# Altium schematic sheet Voltage_Regulator.SchDoc(Voltage_Regulator)
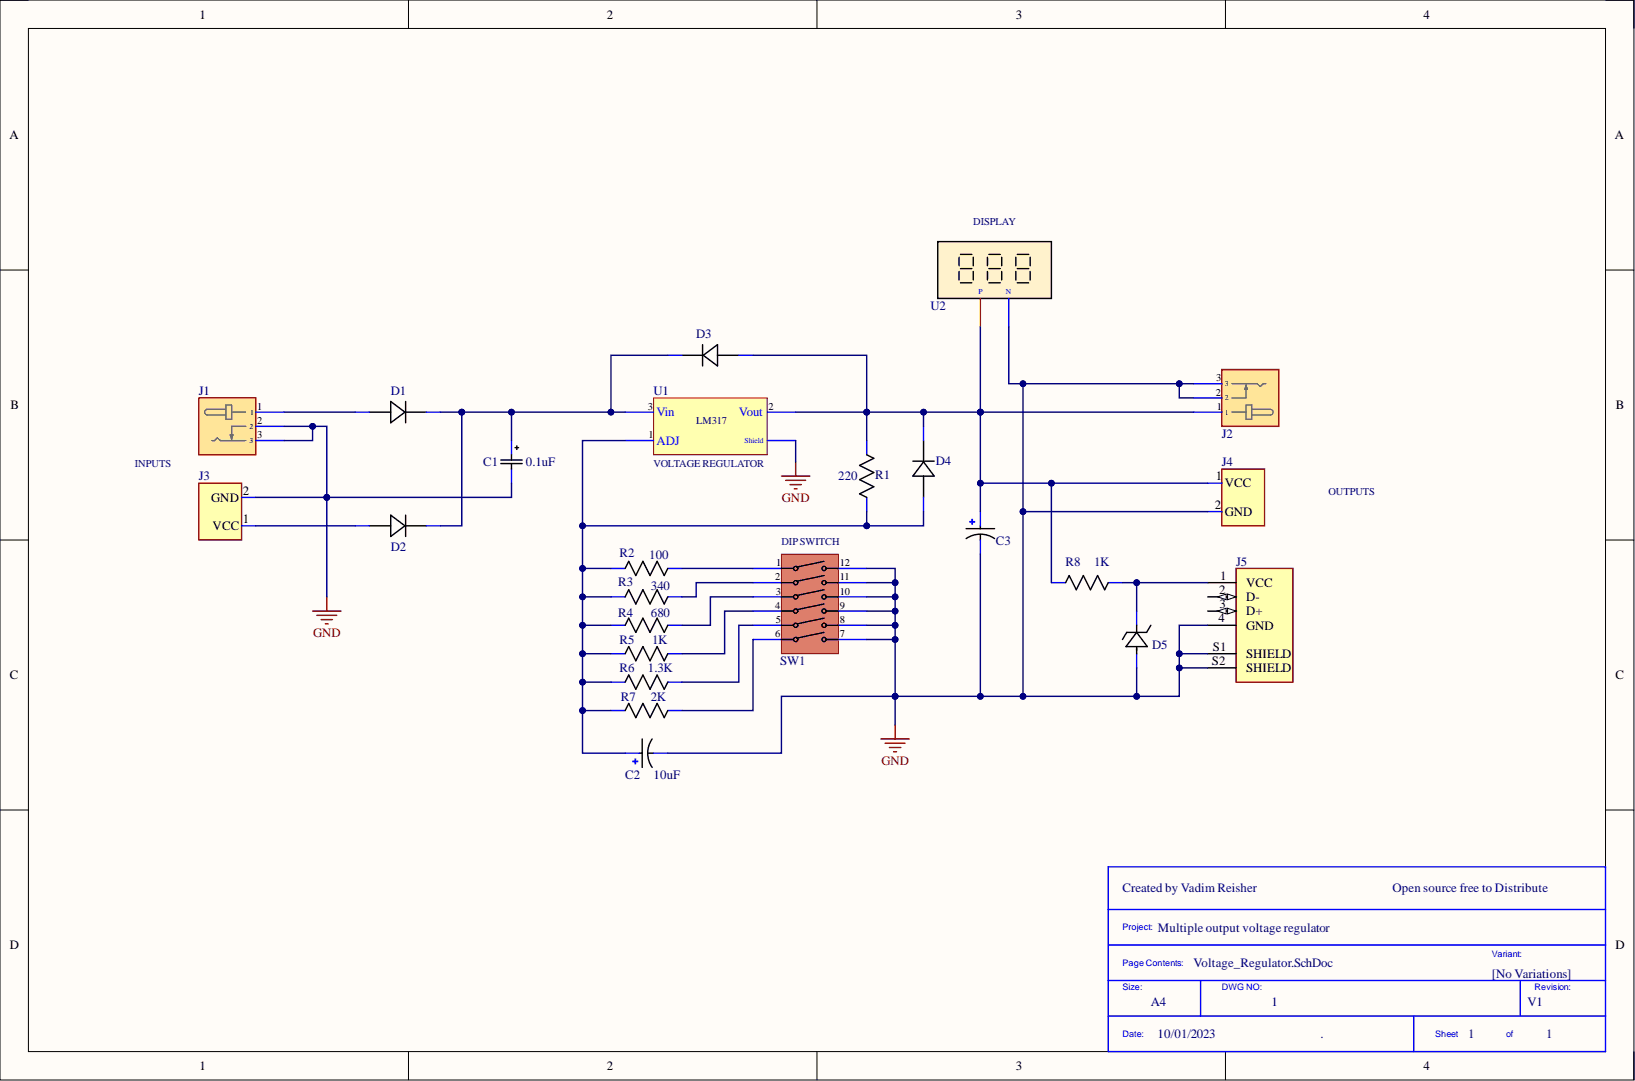
[diagram p0: Voltage_Regulator.SchDoc]

## Parts
C1  0.1uF  [CAP_UEP_5X11_NCH]
C2  10uF  [CAPPRD250W50D500H1100]
C3  ECA-1HM100I  [CAPPRD250W50D500H1100]  (value not drawn)
D1  1N4002  [DIOAD520W79L463D238]  (value not drawn)
D2  1N4002  [DIOAD520W79L463D238]  (value not drawn)
D3  1N4002  [DIOAD520W79L463D238]  (value not drawn)
D4  1N4002  [DIOAD520W79L463D238]  (value not drawn)
D5  1N5231B  [AFL-W2C8.9D]  (value not drawn)
J1  PJ-002A  [PJ-002A]  (value not drawn)
J2  PJ-002A  [PJ-002A]  (value not drawn)
J3  TB003-500-P02BE  [HDR1x2_TB003-500]  (value not drawn)
J4  TB003-500-P02BE  [HDR1x2_TB003-500]  (value not drawn)
J5  USB-A-S-X-X-TH  [SAMTEC_USB-A-S-X-X-TH]  (value not drawn)
R1  220  [CMF55_VIS]
R2  100  [CFR25_YAG]
R3  340  [MF25_YAG]
R4  680  [CFR25_YAG]
R5  1K  [CFR25_YAG]
R6  1.3K  [CFR25_YAG]
R7  2K  [CFR25_YAG]
R8  1K  [CFR25_YAG]
SW1  Case/Package: DIP  [BD06AV]  (hidden comment: BD06AV)
U1  LM317AT_HeatSink  [TO254P1054X470X1955-3 - HeatSink]  (value not drawn)
U2  DSN DVM 368  [DSN-DVM-368]  (value not drawn)

## Nets
GND: C1.2 C2.N C3.N D5.2 J1.2 J1.3 J2.2 J2.3 J3.2 J4.2 J5.4(GND) J5.S1(SHIELD) J5.S2(SHIELD) SW1.7 SW1.8 SW1.9 SW1.10 SW1.11 SW1.12 U1.4(Shield) U2.2
NetC1_1: C1.1 D1.C D2.C D3.C U1.3(Vin)
NetC2_P: C2.P D4.A R1.2 R2.1 R3.1 R4.1 R5.1 R6.1 R7.1 U1.1(ADJ)
NetC3_P: C3.P D3.A D4.C J2.1 J4.1 R1.1 R8.1 U1.2(Vout) U2.1
NetD1_A: D1.A J1.1
NetD2_A: D2.A J3.1
NetD5_1: D5.1 J5.1(VCC) R8.2
NetR2_2: R2.2 SW1.1
NetR3_2: R3.2 SW1.2
NetR4_2: R4.2 SW1.3
NetR5_2: R5.2 SW1.4
NetR6_2: R6.2 SW1.5
NetR7_2: R7.2 SW1.6

Not connected: J5 2(D-) 3(D+)

## Library data of the parts
C1 = UKT1H0R1MDD1TD: CAP ALUM 0.1UF 20% 50V RADIAL; Mfr_Name: Nichicon; Type: DEV; Component Kind: Standard; Digi-Key_Part_Number_1: 493-10656-1-ND; Digi-Key_Part_Number_2: 493-10656-3-ND; Library Name: Voltage_Regulator_SchLib.SchLib; Manufacturer_Part_Number: UKT1H0R1MDD1TD; RefDes: RefDes; Copyright: Copyright (C) 2023 Ultra Librarian. All rights reserved.
C2 C3 = ECA-1HM100I: CAP ALUM 10UF 20% 50V RADIAL; Manufacturer: Panasonic; Dissipation Factor: 0.12%; Lead Diameter: 0.5mm; Lead/Base Style: Straight; Leakage Current: 3uA; Life (Hours): 2000Hour; Ripple Current: 65mA; Case/Package: Radial; Category: Aluminum Electrolytic Capacitors; Component Kind: Standard; ComponentLink1Description: https://datasheet.ciiva.com/16201/aba0000ce12-16201701.pdf?src-supplier=Future+Electronics; ComponentLink1URL: https://datasheet.ciiva.com/16201/aba0000ce12-16201701.pdf?src-supplier=Future+Electronics; ComponentLink2Description: https://datasheet.ciiva.com/24234/aba0000ce12-465472-24234949.pdf?src-supplier=Mouser; ComponentLink2URL: https://datasheet.ciiva.com/24234/aba0000ce12-465472-24234949.pdf?src-supplier=Mouser; ComponentLink3Description: https://datasheet.ciiva.com/28677/aba0000ce12-28677830.pdf?src-supplier=Element14; ComponentLink3URL: https://datasheet.ciiva.com/28677/aba0000ce12-28677830.pdf?src-supplier=Element14; ComponentLink4Description: https://datasheet.ciiva.com/7794/1907780-7794379.pdf?src-supplier=Element14; ComponentLink4URL: https://datasheet.ciiva.com/7794/1907780-7794379.pdf?src-supplier=Element14; ComponentLink5Description: https://datasheet.ciiva.com/2984/aba0000ce12-2984335.pdf?src-supplier=Avnet; ComponentLink5URL: https://datasheet.ciiva.com/2984/aba0000ce12-2984335.pdf?src-supplier=Avnet; ComponentLink6Description: https://datasheet.ciiva.com/6121/aba0000ce12-6121535.pdf?src-supplier=Farnell; ComponentLink6URL: https://datasheet.ciiva.com/6121/aba0000ce12-6121535.pdf?src-supplier=Farnell; ComponentLink7Description: https://datasheet.ciiva.com/14608/70067785-14608821.pdf?src-supplier=Allied+Electronics; ComponentLink7URL: https://datasheet.ciiva.com/14608/70067785-14608821.pdf?src-supplier=Allied+Electronics; ComponentLink8Description: https://datasheet.ciiva.com/15197/getdatasheetpartid-238364-15197946.pdf?src-supplier=Verical; ComponentLink8URL: https://datasheet.ciiva.com/15197/getdatasheetpartid-238364-15197946.pdf?src-supplier=Verical; Construction: RADIAL; Diameter: 5mm; Height: 11mm; Height - Seated (Max): 0.472inch; Lead Free: Lead Free; Lead Pitch: 2.5mm; Length: 11mm; Library Name: Voltage_Regulator_SchLib.SchLib; Manufacturer Part Number: ECA-1HM100I; Max Operating Temperature: 85°C; Min Operating Temperature: -40°C; Mounting Technology: Through Hole,PCB; Package Quantity: 2000; Packaging: Tape and Reel; Pricing 1: 2000=0.05900, 10000=0.05800, 20000=0.05700 (USD); Radiation Hardening: No; RoHS: Yes; RoHS Compliant: Yes; Series: M; Stock 1: 6000; Supplier 1: Farnell; Supplier Part Number 1: 2917901; Termination: Radial; Tolerance: 20%; Value: 10uF; Voltage: 50V; Voltage Rating: 50V; Voltage Rating (DC): 50V
D1-D4 = 1N4002: Diode Standard 100 V 1A Through Hole DO-41; MF: NTE Electronics, Inc; Availability: In Stock; Check_prices: https://www.snapeda.com/parts/1N4002/NTE+Electronics/view-part/?ref=eda; Component Kind: Standard; Library Name: Voltage_Regulator_SchLib.SchLib; MP: 1N4002; Package: DO-41 NTE Electronics; Price: None; Purchase-URL: https://pricing.snapeda.com/search/part/1N4002/?ref=eda; SnapEDA_Link: https://www.snapeda.com/parts/1N4002/NTE+Electronics/view-part/?ref=snap
D5 = 1N5231B: DIODE ZENER 5.1V 500MW DO35; Mfr_Name: onsemi; Type: DEV; Component Kind: Standard; Library Name: Voltage_Regulator_SchLib.SchLib; Manufacturer_Part_Number: 1N5231B; RefDes: RefDes; Copyright: Copyright (C) 2023 Ultra Librarian. All rights reserved.
J1 J2 = PJ-002A: 2.0 x 6.5 mm, 2.5 A, Horizontal, Through Hole, Dc Power Jack Connector; Manufacturer: CUI; Current Rating: 2.5A; Gender: Male; Insulation Resistance: 50MR; Number of Conductors: 2; Shielding: Unshielded; Voltage Rating: 24V; Category: Uncategorized; Color: Black; Component Kind: Standard; ComponentLink1Description: http://www.mouser.com/ds/2/670/pj-002a-515880.pdf?src-supplier=Mouser; ComponentLink1URL: http://www.mouser.com/ds/2/670/pj-002a-515880.pdf?src-supplier=Mouser; ComponentLink2Description: http://www.cui.com/Product/Resource/FuturePDF/PJ-002A.pdf?src-supplier=Future+Electronics; ComponentLink2URL: http://www.cui.com/Product/Resource/FuturePDF/PJ-002A.pdf?src-supplier=Future+Electronics; ComponentLink3Description: https://www.cui.com/product/resource/digikeypdf/pj-002a.pdf?src-supplier=Digi-Key; ComponentLink3URL: https://www.cui.com/product/resource/digikeypdf/pj-002a.pdf?src-supplier=Digi-Key; Connector Type: Jack; Contact Gender: Male; Contact Material: Copper Alloy,Copper; Contact Plating: Tin,Nickel; Diameter: 2.1mm; Flammability Rating: UL94 V-0; Housing Color: Black; Housing Material: Thermoplastic; Lead Free: Lead Free; Library Name: Voltage_Regulator_SchLib.SchLib; Manufacturer Part Number: PJ-002A; Max Operating Temperature: 85°C; Min Operating Temperature: -25°C; Mounting Technology: Through Hole; Orientation: Horizontal; Package Quantity: 500; Packaging: Bulk; RoHS: Yes; RoHS Compliant: Yes; Series: -; Supplier 1: Arrow Electronics; Supplier Part Number 1: PJ-002A; Termination: Through Hole
J3 J4 = 1x2 Header: 2 Position Wire to Board Terminal Block Horizontal with Board 0.197" (5.00mm) Through Hole; Manufacturer: CUI Devices; Category: Terminal Blocks; Component Kind: Standard; Library Name: Voltage_Regulator_SchLib.SchLib; Manufacturer Part Number: TB003-500-P02BE; Pricing 1: 1=0.73000, 10=0.39800, 25=0.29720, 50=0.26500, 100=0.25990, 250=0.22868, 500=0.20790, 3000=0.14553, 6000=0.14033 (USD); RoHS: Yes; Stock 1: 20513; Supplier 1: Digi-Key; Supplier Part Number 1: 102-6161-ND
J5 = USB-A-S-X-X-TH: USB-A (USB TYPE-A) USB 2.0 Receptacle Connector 4 Position Through Hole, Right Angle; MF: Samtec; MP: USB-A-S-S-W-TH; Component Kind: Standard; Digi-Key_Part_Number: SAM10373-ND; Library Name: Voltage_Regulator_SchLib.SchLib; Package: None; SnapEDA_Link: https://www.snapeda.com/parts/USB-A-S-S-W-TH/Samtec%20Inc./view-part/423470/?ref=snap; Toby_UK-Purchase-URL: https://snapeda.com/shop?store=Toby+UK&id=423470
R1 = RN55D2200FRE6_1: RES 220 OHM 1/8W 1% AXIAL; Mfr_Name: Vishay; Component Kind: Standard; Digi-Key_Part_Number_1: RN55D2200FRE6-ND; Digi-Key_Part_Number_2: 541-RN55D2200FRE6CT-ND; Digi-Key_Part_Number_3: 541-RN55D2200FRE6TR-ND; Library Name: Voltage_Regulator_SchLib.SchLib; Manufacturer_Part_Number: RN55D2200FRE6; RefDes: RefDes; Type: DEV; Copyright: Copyright (C) 2022 Ultra Librarian. All rights reserved.
R2 = CFR-25JR-52-100R: RES 100 OHM 5% 1/4W AXIAL; Mfr_Name: Yageo; Component Kind: Standard; Digi-Key_Part_Number_1: 100QTR-ND; Library Name: Voltage_Regulator_SchLib.SchLib; Manufacturer_Part_Number: CFR-25JR-52-100R; RefDes: RefDes; Type: DEV; Copyright: Copyright (C) 2022 Ultra Librarian. All rights reserved.
R3 = MFR-25FBF52-340R: RES 340 OHM 1% 1/4W AXIAL; Manufacturer: Yageo; Max Power Dissipation: 250mW; Temperature Coefficient: 100ppm/°C; Value: 340R; Voltage Rating: 250V; Voltage Rating (DC): 200V; Case/Package: Axial; Category: Through-Hole Resistors; Component Kind: Standard; ComponentLink1Description: http://www.mouser.com/ds/2/447/Yageo%20LR_MFR_2013-467719.pdf?src-supplier=Mouser; ComponentLink1URL: http://www.mouser.com/ds/2/447/Yageo%20LR_MFR_2013-467719.pdf?src-supplier=Mouser; ComponentLink2Description: http://www.yageo.com/documents/recent/Yageo%20LR_MFR_2013.pdf?src-supplier=Digi-Key; ComponentLink2URL: http://www.yageo.com/documents/recent/Yageo%20LR_MFR_2013.pdf?src-supplier=Digi-Key; Length: 0.248inch; Library Name: Voltage_Regulator_SchLib.SchLib; Manufacturer Part Number: MFR-25FBF52-340R; Max Operating Temperature: 155°C; Min Operating Temperature: -55°C; Number of Terminations: 2; Package Quantity: 200; Packaging: Bulk; Power Rating: 250mW; Pricing 1: 1=0.10000, 10=0.08100, 25=0.05600, 50=0.04360, 100=0.03110, 250=0.02428, 500=0.01928, 1000=0.01369, 5000=0.01058 (USD); Resistor Type: Metal Film; RoHS: Yes; Stock 1: 13988; Supplier 1: Digi-Key; Supplier Part Number 1: 340XBK-ND; Termination: Axial; Tolerance: 1%
R4 = CFR-25JB-52-680R: RES 680 OHM 5% 1/4W AXIAL; Manufacturer: Yageo; Max Power Dissipation: 250mW; Temperature Coefficient: 500ppm/°C; Value: 680R; Case/Package: Axial; Category: Through-Hole Resistors; Component Kind: Standard; ComponentLink1Description: http://www.yageo.com/NewPortal/yageodocoutput?fileName=/pdf/throughhole/Yageo_LR_CFR_2013.pdf&src-supplier=Digi-Key; ComponentLink1URL: http://www.yageo.com/NewPortal/yageodocoutput?fileName=/pdf/throughhole/Yageo_LR_CFR_2013.pdf&src-supplier=Digi-Key; Length: 0.248inch; Library Name: Voltage_Regulator_SchLib.SchLib; Manufacturer Part Number: CFR-25JB-52-680R; Max Operating Temperature: 155°C; Min Operating Temperature: -55°C; Number of Terminations: 2; Package Quantity: 200; Packaging: Bulk; Pricing 1: 10=0.04700, 100=0.02200, 500=0.01200, 2500=0.01000, 5000=0.00900, 10000=0.00900, 50000=0.00900 (USD); Resistor Type: Carbon Film; RoHS: Yes; RoHS Compliant: Yes; Series: Carbon Film; Stock 1: 10000; Supplier 1: Farnell; Supplier Part Number 1: 3951871; Tolerance: 5%
R5 R8 = CFR-25JR-52-1K: RES 1K OHM 5% 1/4W AXIAL; Manufacturer: Yageo; Lead Diameter: 0.55mm; Max Power Dissipation: 250mW; Temperature Coefficient: 350ppm/°C; Value: 1kR; Voltage Rating: 250V; Case/Package: Conformal; Category: Through-Hole Resistors; Component Kind: Standard; ComponentLink1Description: https://datasheet.ciiva.com/26863/getdatasheetpartid-671236-26863217.pdf?src-supplier=Verical; ComponentLink1URL: https://datasheet.ciiva.com/26863/getdatasheetpartid-671236-26863217.pdf?src-supplier=Verical; Construction: Cylindrical; Contact Plating: Tin,Matte; Diameter: 2.4mm; Lead Free: Lead Free; Length: 6.3mm; Library Name: Voltage_Regulator_SchLib.SchLib; Manufacturer Part Number: CFR-25JR-52-1K; Max Operating Temperature: 155°C; Military Standard: Not; Min Operating Temperature: -55°C; Mounting Technology: Through Hole; Number of Terminations: 2; Package Quantity: 5000; Packaging: Tape and Reel; Power Rating: 250mW; Pricing 1: 10=0.04700, 100=0.02200, 500=0.01600, 1000=0.01200, 2500=0.01000, 5000=0.00800, 25000=0.00800 (USD); Radiation Hardening: No; Resistor Type: Carbon Film; RoHS: Yes; RoHS Compliant: Yes; Series: CFR; Stock 1: 24840; Supplier 1: Farnell; Supplier Part Number 1: 3951801; Termination: Axial; Tolerance: 5%
R6 = CFR-25JB-52-1K3: RES 1.3K OHM 5% 1/4W AXIAL; Manufacturer: Yageo; Max Power Dissipation: 250mW; Temperature Coefficient: 500ppm/°C; Value: 1.3kR; Case/Package: Axial; Category: Through-Hole Resistors; Component Kind: Standard; ComponentLink1Description: http://www.yageo.com/NewPortal/yageodocoutput?fileName=/pdf/throughhole/Yageo_LR_CFR_2013.pdf&src-supplier=Digi-Key; ComponentLink1URL: http://www.yageo.com/NewPortal/yageodocoutput?fileName=/pdf/throughhole/Yageo_LR_CFR_2013.pdf&src-supplier=Digi-Key; Length: 0.248inch; Library Name: Voltage_Regulator_SchLib.SchLib; Manufacturer Part Number: CFR-25JB-52-1K3; Max Operating Temperature: 155°C; Min Operating Temperature: -55°C; Number of Terminations: 2; Package Quantity: 200; Packaging: Bulk; Pricing 1: 1=0.10000, 10=0.06800, 25=0.04680, 50=0.03640, 100=0.02600, 250=0.02028, 500=0.01612, 1000=0.01144, 5000=0.00884 (USD); Resistor Type: Carbon Film; RoHS: Yes; RoHS Compliant: Yes; Series: Carbon Film; Stock 1: 702; Supplier 1: Digi-Key; Supplier Part Number 1: 1.3KQBK-ND; Tolerance: 5%
R7 = CFR-25JR-52-2K: RES 2K OHM 5% 1/4W AXIAL; Manufacturer: Yageo; Lead Diameter: 0.55mm; Max Power Dissipation: 250mW; Temperature Coefficient: 500ppm/°C; Value: 2kR; Voltage Rating: 250V; Case/Package: Axial; Category: Through-Hole Resistors; Component Kind: Standard; ComponentLink1Description: http://www.mouser.com/ds/2/447/Yageo%20LR_CFR_2013-540723.pdf?src-supplier=Mouser; ComponentLink1URL: http://www.mouser.com/ds/2/447/Yageo%20LR_CFR_2013-540723.pdf?src-supplier=Mouser; ComponentLink2Description: http://www.yageo.com/documents/recent/Yageo%20LR_CFR_2013.pdf?src-supplier=Digi-Key; ComponentLink2URL: http://www.yageo.com/documents/recent/Yageo%20LR_CFR_2013.pdf?src-supplier=Digi-Key; ComponentLink3Description: http://www.yageo.com/documents/recent/General%20Type_CFR.pdf?src-supplier=Future+Electronics; ComponentLink3URL: http://www.yageo.com/documents/recent/General%20Type_CFR.pdf?src-supplier=Future+Electronics; Lead Free: Lead Free; Length: 0.248inch; Library Name: Voltage_Regulator_SchLib.SchLib; Manufacturer Part Number: CFR-25JR-52-2K; Max Operating Temperature: 155°C; Min Operating Temperature: -55°C; Mounting Technology: Through Hole; Number of Terminations: 2; Package Quantity: 5000; Packaging: Tape and Reel; Power Rating: 250mW; Pricing 1: 1=0.10000, 10=0.06800, 25=0.04680, 50=0.03640, 100=0.02600, 250=0.02028, 500=0.01612, 1000=0.01144 (USD); Resistor Type: Carbon Film; RoHS: Yes; RoHS Compliant: Yes; Series: CFR; Stock 1: 57804; Supplier 1: Digi-Key; Supplier Part Number 1: 13-CFR-25JR-52-2KCT-ND; Termination: Axial; Tolerance: 5%
SW1 = BD06AV: Switch DIP OFF ON SPST 6 Extended Slide 0.1A 25VDC PC Pins 10000Cycles 2.54mm Thru-Hole Tube; Manufacturer: ITT C&K; Actuator Length: 0.9mm; Actuator Material: Nylon,Glass; Actuator Type: Slide (Standard); Body Material: PBT,Glass,Polybutylene; Contact Current Rating: 100mA; Max Voltage Rating (DC): 25V; Number of Positions: 6; Pitch: 0.1inch; Washable: Yes; Category: DIP Switches; Component Kind: Standard; ComponentLink1Description: https://datasheet.ciiva.com/16199/844bd-4feb-16199463.pdf?src-supplier=Onlinecomponents.com; ComponentLink1URL: https://datasheet.ciiva.com/16199/844bd-4feb-16199463.pdf?src-supplier=Onlinecomponents.com; ComponentLink2Description: https://datasheet.ciiva.com/2863/bd-17mar15-2863821.pdf?src-supplier=Digi-Key; ComponentLink2URL: https://datasheet.ciiva.com/2863/bd-17mar15-2863821.pdf?src-supplier=Digi-Key; Contact Material: Silver,Bronze,Gold; Contact Plating: Silver,Gold,Tin,Matte; Current Rating: 100mA; Depth: 11.69mm; Electrical Life: 10000Cycles; Lead Free: Lead Free; Length: 0.68inch; Library Name: Voltage_Regulator_SchLib.SchLib; Manufacturer Part Number: BD06AV; Max Current Rating: 100mA; Max Operating Temperature: 85°C; Mechanical Life: 10000Cycles; Min Operating Temperature: -40°C; Mounting Technology: Through Hole; Orientation: Right Angle; Package Quantity: 29; Packaging: Rail/Tube; Pricing 1: 1=3.17900, 10=2.98400, 29=2.77200, 58=2.56700, 87=2.30700, 232=2.28400, 464=2.19900, 957=1.86200, 4901=1.86100 (USD); Radiation Hardening: No; RoHS: Yes; Stock 1: 735; Supplier 1: Arrow Electronics; Supplier Part Number 1: BD06AV; Terminal Pitch: 2.54mm; Termination: PC Pins; Throw Configuration: SPST; Voltage Rating (DC): 25V
U1 = LM317AT_HeatSink: IC REG LIN POS ADJ 1.5A TO220-3 with Heatsing; Component Kind: Standard; Library Name: Voltage_Regulator_SchLib.SchLib
U2 = DSN DVM 368: 7 Segment Display DC 0-100V; Component Kind: Standard; Library Name: Voltage_Regulator_SchLib.SchLib
